# Altium schematic sheet Battery.SchDoc
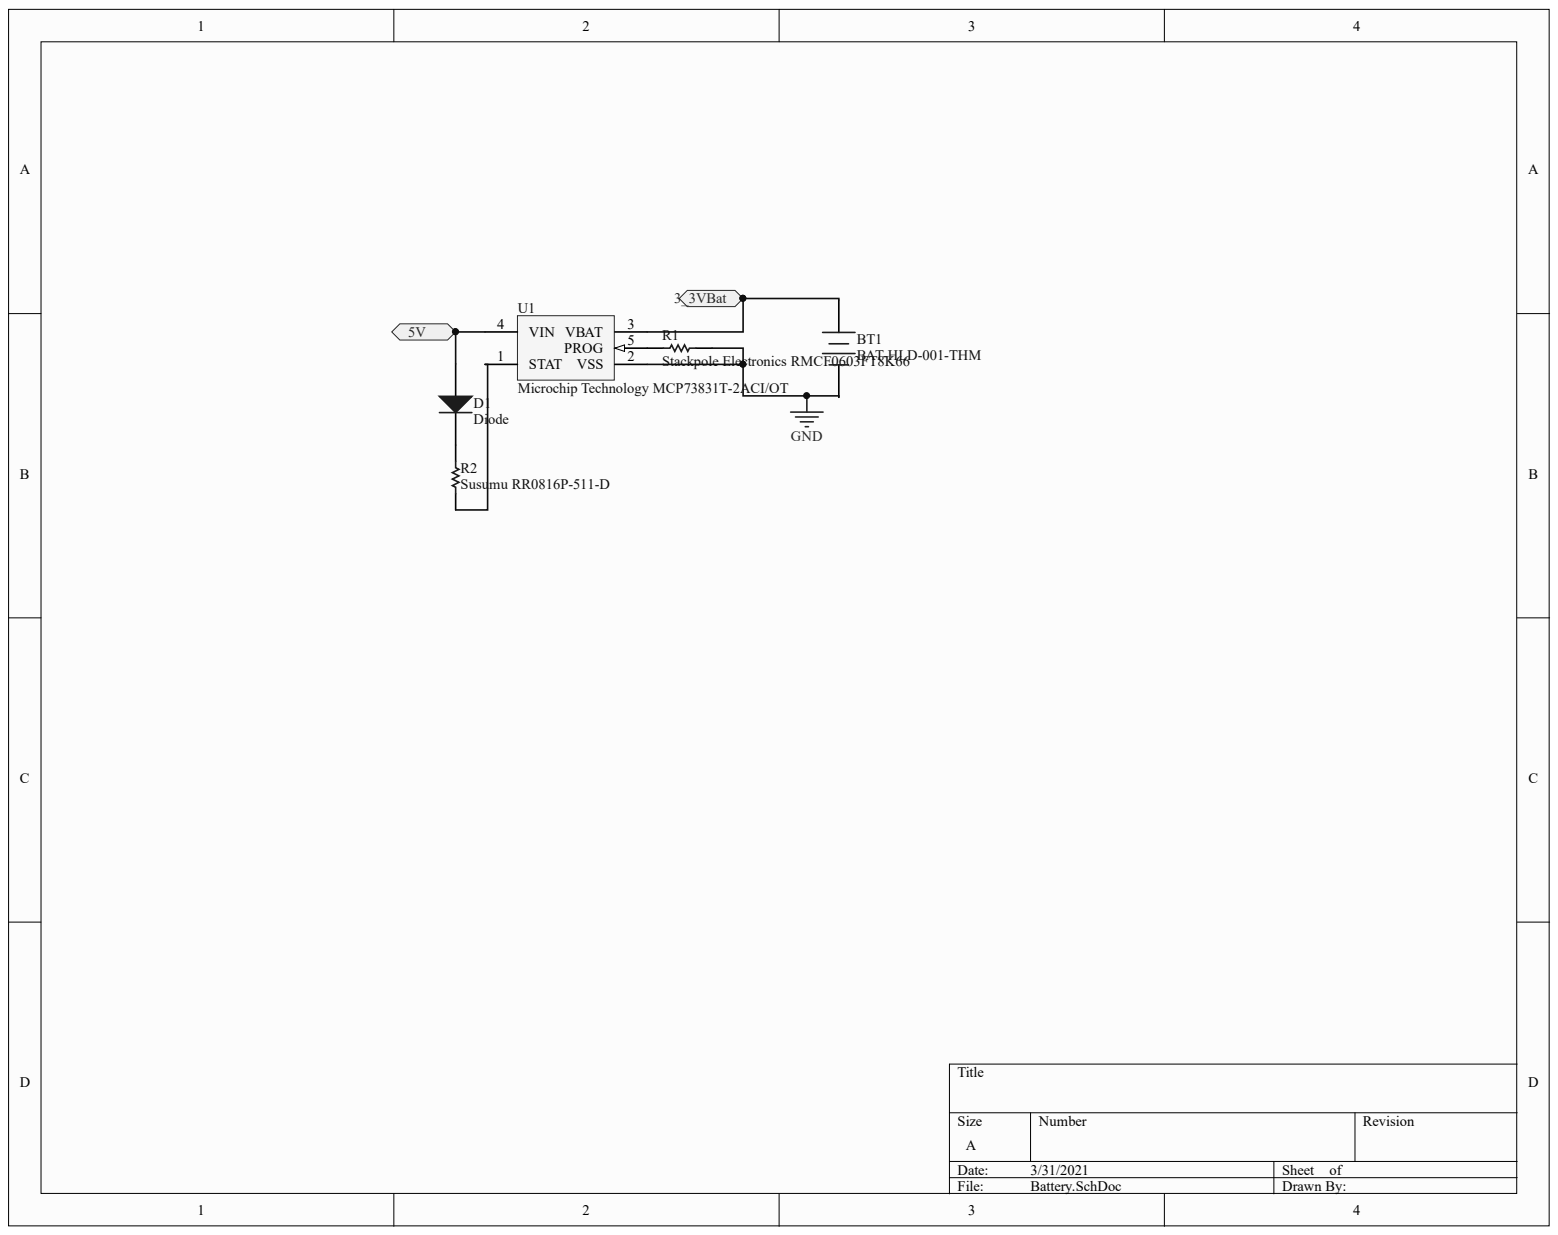
[diagram p0: Battery.SchDoc]

## Parts
BT1  BAT-HLD-001-THM  [PCBComponent_1 - duplicate]
D1  Diode  [PCB-6c3zjm4k1kvwna5xzjsx-1]
R1  Stackpole Electronics RMCF0603FT8K66  [CAPC1708X06N]
R2  Susumu RR0816P-511-D  [RESC1608X50X30LL20T20]
U1  Microchip Technology MCP73831T-2ACI/OT  Manufacturer Part Number: MCP73831T-2ACI/OT  [SOT23-5_D]

## Ports
3_3VBAT, 5V

## Nets
3_3VBAT: BT1.1 U1.3(VBAT) ; port 3_3VBAT
GND: BT1.2 R1.2 U1.2(VSS)
5V: D1.1 U1.4(VIN) ; port 5V
NetD1_2: D1.2 R2.2
NetR1_1: R1.1 U1.5(PROG)
NetR2_1: R2.1 U1.1(STAT)

## Library data of the parts
BT1 = CMP-c866003ddb1617a1-7: Battery Holder CR2025/2032 Through Hole Mount; Component Kind: Standard; Library Name: CommunityVault; Manufacturer Part Number: BAT-HLD-001-THM; RoHS: Compliant
D1 = CMP-8bcb47e0445b540c-1: IN-S63AT Series White 0603 120° 900 mcd 3.9 V Surface Mount Top View LED; Component Kind: Standard; Library Name: CommunityVault
R1 = CMP-2e03e62c2885f1c4-1: Res Thick Film 0603 8.66K Ohm 1% 1/10W ±100ppm/°C Molded SMD SMD Paper T/R; Lead-Free Status: Lead Free; Power Rating: 100 mW; Resistance: 8.66 k?; Case/Package: 0603; Component Kind: Standard; Library Name: FavoritesLibrary; Manufacturer Part Number: RMCF0603FT8K66; Mounting Style: Surface Mount; OctopartID: 2e03e62c2885f1c4; Octopart URL: https://octopart.com/rmcf0603ft8k66-stackpole+electronics-19211232; Packaging: Tape & Reel (TR); Resistance Tolerance: ±1%; RoHS: Compliant
R2 = CMP-1864586-2: RES SMD 510 OHM 0.5% 1/16W 0816; Lead-Free Status: Lead Free; Power Rating: 0.063 W; Resistance: 510.0 Ω; Voltage Rating (DC): 75.0 V; Case/Package: 0603; Component Kind: Standard; Library Name: CommunityVault; Manufacturer Part Number: RR0816P-511-D; Mounting Style: Surface Mount; Number of Pins: 2; OctopartID: 3927f023e603e6e0; Octopart Url: https://octopart.com/rr0816p-511-d-susumu-981570; Packaging: Cut Tape (CT); Resistance Tolerance: ±0.5%; RoHS: Compliant; Size-Height: 0.0004 m; Size-Length: 0.0016 m; Size-Width: 0.0008 m
U1 = CMP-961385-12: MCP73831 Series Single-Cell Li-Ion/Li-Polymer Battery Charge Controller SOT-23-5; Input Voltage (DC): 3.75 V (min); Lead-Free Status: Lead Free; Output Voltage: 7.30 V; Supply Voltage (DC): 6.00 V (max); Case/Package: SOT-23; Component Kind: Standard; Library Name: CommunityVault; Lifecycle Status: Active; Mounting Style: Surface Mount; Number of Pins: 5; OctopartID: d79a1dddcae5085d; Octopart Url: http://octopart.com/mcp73831t-2aci%2Fot-microchip-55392281; Packaging: Cut Tape (CT), Tape & Reel (TR); REACH SVHC Compliance: No SVHC; RoHS: Compliant; Seller Landing  Url: https://octopart-clicks.com/click/track?country=US&ak=8157f166&sig=05342b1&sid=459&ppid=55392281&vpid=188043932&ct=offers
